# Altium schematic sheet Wires1.SchDoc
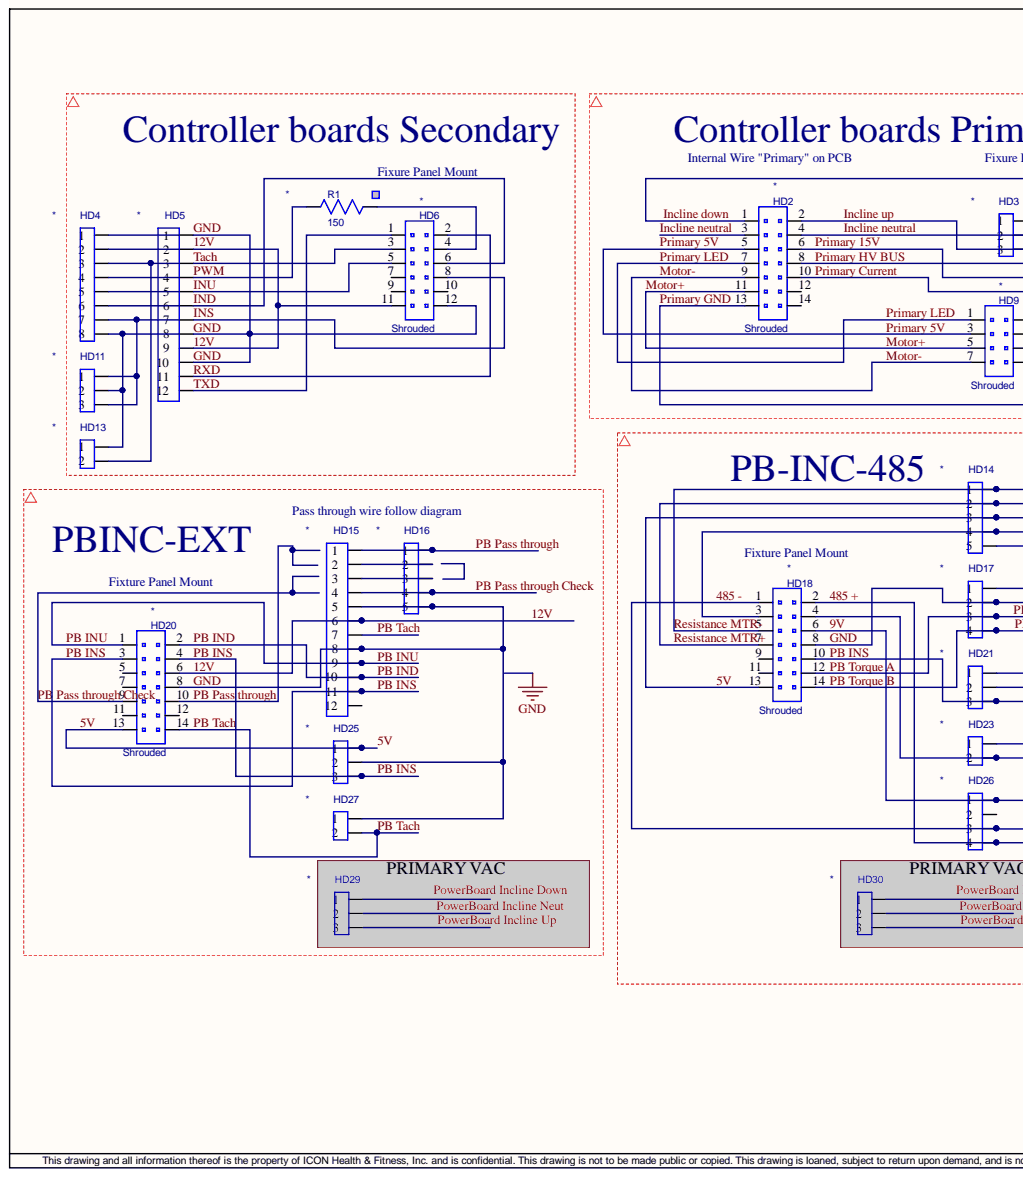
[diagram p0: Wires1.SchDoc, left]
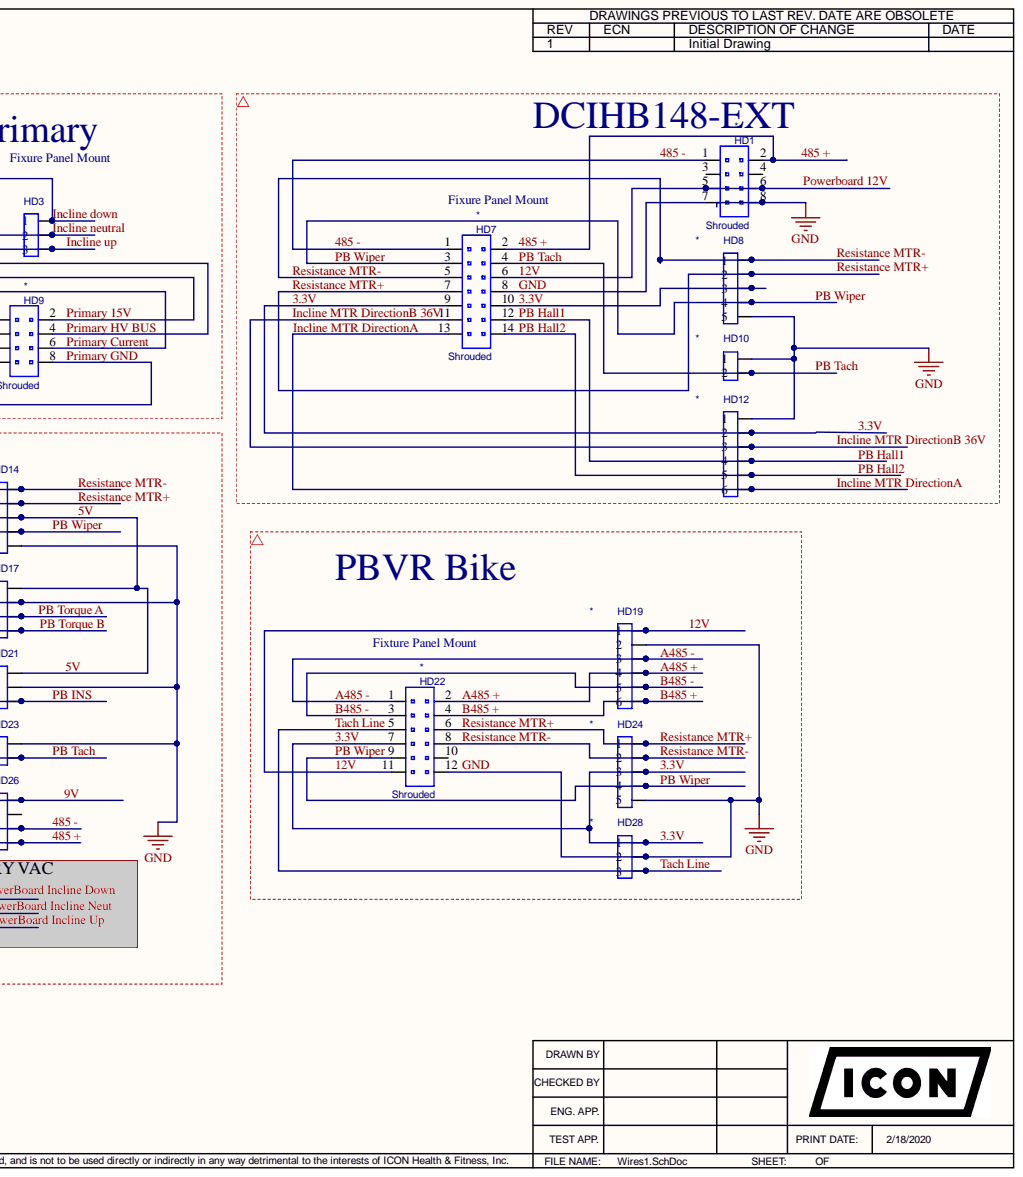
[diagram p1: Wires1.SchDoc, right]

## Parts
HD1  HeaderType: Shrouded  [HDR_2.5MM_8PIN_JST_XAD]  (hidden comment: HDR_8PIN_DUALROW)
HD2  HeaderType: Shrouded  [HDR_2.5MM_14PIN_JST_XAD]  (hidden comment: HDR_14PIN_DUALROW_JST)
HD3  HDR3PIN  [HDR3PIN_JST_XA_2.5MM]  (value not drawn)
HD4  HDR8PIN  [HDR8PIN_JST_XA_2.5MM]  (value not drawn)
HD5  HDR12PIN  [HDR12PIN_JST_XA_2.5MM]  (value not drawn)
HD6  HeaderType: Shrouded  [HDR_2.5MM_12PIN_JST_XAD]  (hidden comment: HDR_12PIN_DUALROW)
HD7  HeaderType: Shrouded  [HDR_2.5MM_14PIN_JST_XAD]  (hidden comment: HDR_14PIN_DUALROW_JST)
HD8  HeaderType: Shrouded  [HDR5PIN_JST_XA_2.5MM]  (hidden comment: HDR5PIN)
HD9  HeaderType: Shrouded  [HDR_2.5MM_8PIN_JST_XAD]  (hidden comment: HDR_8PIN_DUALROW)
HD10  HDR2PIN  [HDR2PIN_JST_XA_2.5MM]  (value not drawn)
HD11  HDR3PIN  [HDR3PIN_JST_XA_2.5MM]  (value not drawn)
HD12  HDR6PIN  [HDR6PIN_JST_XA_2.5MM]  (value not drawn)
HD13  HDR2PIN  [HDR2PIN_JST_XA_2.5MM]  (value not drawn)
HD14  HDR5PIN  [HDR5PIN_JST_XA_2.5MM]  (value not drawn)
HD15  HDR12PIN  [HDR12PIN_JST_XA_2.5MM]  (value not drawn)
HD16  HDR5PIN  [HDR5PIN_JST_XA_2.5MM]  (value not drawn)
HD17  HDR4PIN  [HDR4PIN_JST_XA_2.5MM]  (value not drawn)
HD18  HeaderType: Shrouded  [HDR_2.5MM_14PIN_JST_XAD]  (hidden comment: HDR_14PIN_DUALROW_JST)
HD19  HDR6PIN  [HDR6PIN_JST_XA_2.5MM]  (value not drawn)
HD20  HeaderType: Shrouded  [HDR_2.5MM_14PIN_JST_XAD]  (hidden comment: HDR_14PIN_DUALROW_JST)
HD21  HDR3PIN  [HDR3PIN_JST_XA_2.5MM]  (value not drawn)
HD22  HeaderType: Shrouded  [HDR_2.5MM_12PIN_JST_XAD]  (hidden comment: HDR_12PIN_DUALROW)
HD23  HDR2PIN  [HDR2PIN_JST_XA_2.5MM]  (value not drawn)
HD24  HDR5PIN  [HDR5PIN_JST_XA_2.5MM]  (value not drawn)
HD25  HDR3PIN  [HDR3PIN_JST_XA_2.5MM]  (value not drawn)
HD26  HDR4PIN  [HDR4PIN_JST_XA_2.5MM]  (value not drawn)
HD27  HDR2PIN  [HDR2PIN_JST_XA_2.5MM]  (value not drawn)
HD28  HDR3PIN  [HDR3PIN_JST_XA_2.5MM]  (value not drawn)
HD29  HDR3PIN  [HDR3PIN_JST_XA_2.5MM]  (value not drawn)
HD30  HDR3PIN  [HDR3PIN_JST_XA_2.5MM]  (value not drawn)
R1  value 150  [R400/70100/42]  (hidden comment: R400/107/42_5%)

## Nets
3.3V: HD7.9 HD7.10 HD8.3 HD12.2 HD22.7 HD24.3 HD28.1
5V: HD14.3 HD17.1 HD18.13 HD20.13 HD21.1 HD25.1
9V: HD18.6 HD26.1
12V: HD1.5 HD1.6 HD5.2 HD5.9 HD6.11 HD7.6 HD15.6 HD19.1 HD20.6 HD22.11
485 +: HD1.2 HD7.2 HD18.2 HD26.4
485 -: HD1.1 HD7.1 HD18.1 HD26.3
A485 +: HD19.4 HD22.2
A485 -: HD19.3 HD22.1
B485 +: HD19.6 HD22.4
B485 -: HD19.5 HD22.3
GND: HD1.7 HD1.8 HD5.1 HD5.8 HD5.10 HD6.12 HD7.8 HD8.5 HD10.1 HD12.1 HD14.5 HD15.5 HD15.8 HD16.5 HD17.2 HD18.8 HD19.2 HD20.8 HD21.2 HD22.12 HD23.1 HD24.5 HD25.2 HD27.1 HD28.2
Incline down: HD2.1 HD3.1
Incline MTR DirectionA: HD7.13 HD12.6
Incline MTR DirectionB 36V: HD7.11 HD12.3
Incline neutral: HD2.3 HD2.4 HD3.2
Incline up: HD2.2 HD3.3
IND: HD5.6 HD6.6
INS: HD5.7 HD6.8
INU: HD5.5 HD6.5
Motor+: HD2.11 HD9.5
Motor-: HD2.9 HD9.7
PB Hall1: HD7.12 HD12.4
PB Hall2: HD7.14 HD12.5
PB IND: HD15.10 HD20.2
PB INS: HD15.11 HD18.10 HD20.3 HD20.4 HD21.3 HD25.3
PB INU: HD15.9 HD20.1
PB Pass through: HD15.1 HD16.1 HD20.10
PB Pass through Check: HD15.4 HD16.4 HD20.9
PB Tach: HD7.4 HD10.2 HD15.7 HD18.4 HD20.14 HD23.2 HD27.2
PB Torque A: HD17.3 HD18.12
PB Torque B: HD17.4 HD18.14
PB Wiper: HD7.3 HD8.4 HD14.4 HD18.3 HD22.9 HD24.4
Primary 5V: HD2.5 HD9.3
Primary 15V: HD2.6 HD9.2
Primary Current: HD2.10 HD9.6
Primary GND: HD2.13 HD9.8
Primary HV BUS: HD2.8 HD9.4
Primary LED: HD2.7 HD9.1
PWM: HD5.4 R1.2
Resistance MTR+: HD7.7 HD8.2 HD14.2 HD18.7 HD22.6 HD24.1
Resistance MTR-: HD7.5 HD8.1 HD14.1 HD18.5 HD22.8 HD24.2
RXD: HD5.11 HD6.2
Tach: HD5.3 HD6.3
Tach Line: HD22.5 HD28.3
TXD: HD5.12 HD6.1
NetHD4_3: HD4.3 HD13.2
NetHD4_7: HD4.7 HD11.1 HD11.3
NetHD4_8: HD4.8 HD11.2 HD13.1
NetHD6_4: HD6.4 R1.1
NetHD15_2: HD15.2 HD16.2
NetHD15_3: HD15.3 HD16.3
N00022:
PowerBoard Incline Down:
PowerBoard Incline Neut:
PowerBoard Incline Up:

Not connected: HD1 3 4; HD2 12 14; HD4 1 2 4 5 6; HD6 7 9 10; HD15 12; HD18 9 11; HD20 5 7 11 12; HD22 10; HD26 2; HD29 1 2 3; HD30 1 2 3

## Library data of the parts
HD1 HD9 = 349833: Header, 8 Pins, 2.50mm, Vertical, Through hole, dual row, Shrouded, JST: B08B-XADSS-N-A; Database Table Name: AltiumComponents; Component Kind: Standard; ComponentLink1Description: Datasheet; ComponentLink1URL: \\iconfitness.com\loganfiles\RND Departments\electronics\accel_lib\Datasheets\eXAD1.pdf; ComponentLink4Description: PDM Parts Maintenance; ComponentLink4URL: https://pdm.iconfitness.com/PDM/EBOM/PartsMaintenance/PartsMaintenance.aspx?Editplidx=349833; ComponentType: Header; Cost: 0.17; LeadSpacing: 2.50mm; Package: Vertical, Through hole, dual row; Pins: 8; plIDX: 349833; Value: B08B-XADSS-N-A; Vendor: JST: B08B-XADSS-N-A; CreatedBy: Rui Zhang; LastUpdatedBy: Rui Zhang; Library Name: bome.DbLib
HD2 HD7 HD18 HD20 = 365385: Header, 14 Pins, 2.50mm, Vertical, Through hole, double row, Shrouded, JST: B14B-XADSS-N-A; Database Table Name: AltiumComponents; Component Kind: Standard; ComponentLink1Description: Datasheet; ComponentLink1URL: \\iconfitness.com\loganfiles\RND Departments\electronics\accel_lib\Datasheets\eXA1.pdf; ComponentLink4Description: PDM Parts Maintenance; ComponentLink4URL: https://pdm.iconfitness.com/PDM/EBOM/PartsMaintenance/PartsMaintenance.aspx?Editplidx=365385; ComponentType: Header; Cost: 0; LeadSpacing: 2.50mm; Package: Vertical, Through hole, double row; Pins: 14; plIDX: 365385; Value: B14B-XADSS-N-A; Vendor: JST: B14B-XADSS-N-A; CreatedBy: Ross Bunnell; LastUpdatedBy: Ross Bunnell; Library Name: bome.DbLib
HD3 HD11 HD21 HD25 HD28-HD30 = 342209: Header, 3 Pins, 2.50mm, Vertical, Through hole, single row, Shrouded, JST: B03B-XASK-1-A; Database Table Name: AltiumComponents; Component Kind: Standard; ComponentLink1Description: Datasheet; ComponentLink1URL: \\iconfitness.com\loganfiles\RND Departments\electronics\accel_lib\Datasheets\eXA1.pdf; ComponentLink4Description: PDM Parts Maintenance; ComponentLink4URL: https://pdm.iconfitness.com/PDM/EBOM/PartsMaintenance/PartsMaintenance.aspx?Editplidx=342209; ComponentType: Header; Cost: 0.0592; HeaderType: Shrouded; LeadSpacing: 2.50mm; Package: Vertical, Through hole, single row; Pins: 3; plIDX: 342209; Vendor: JST: B03B-XASK-1-A; CreatedBy: Michael Angeli; LastUpdatedBy: Michael Angeli; Library Name: bome.DbLib
HD4 = 342214: Header, 8 Pins, 2.50mm, Vertical, Through hole, single row, Shrouded, JST: B08B-XASK-1-A; Database Table Name: AltiumComponents; Component Kind: Standard; ComponentLink1Description: Datasheet; ComponentLink1URL: \\iconfitness.com\loganfiles\RND Departments\electronics\accel_lib\Datasheets\eXA1.pdf; ComponentLink4Description: PDM Parts Maintenance; ComponentLink4URL: https://pdm.iconfitness.com/PDM/EBOM/PartsMaintenance/PartsMaintenance.aspx?Editplidx=342214; ComponentType: Header; Cost: 0.1271; HeaderType: Shrouded; LeadSpacing: 2.50mm; Package: Vertical, Through hole, single row; Pins: 8; plIDX: 342214; Vendor: JST: B08B-XASK-1-A; CreatedBy: Michael Angeli; LastUpdatedBy: Michael Angeli; Library Name: bome.DbLib
HD5 HD15 = 342218: Header, 12 Pins, 2.50mm, Vertical, Through hole, single row, Shrouded, JST: B12B-XASK-1-A; Database Table Name: AltiumComponents; Component Kind: Standard; ComponentLink1Description: Datasheet; ComponentLink1URL: \\iconfitness.com\loganfiles\RND Departments\electronics\accel_lib\Datasheets\eXA1.pdf; ComponentLink4Description: PDM Parts Maintenance; ComponentLink4URL: https://pdm.iconfitness.com/PDM/EBOM/PartsMaintenance/PartsMaintenance.aspx?Editplidx=342218; ComponentType: Header; Cost: 0.15; HeaderType: Shrouded; LeadSpacing: 2.50mm; Package: Vertical, Through hole, single row; Pins: 12; plIDX: 342218; Vendor: JST: B12B-XASK-1-A; CreatedBy: Michael Angeli; LastUpdatedBy: Michael Angeli; Library Name: bome.DbLib
HD6 HD22 = 349864: Header, 12 Pins, 2.50mm, Vertical, Through hole, dual row, Shrouded, JST: B12B-XADSS-N-A; Database Table Name: AltiumComponents; Component Kind: Standard; ComponentLink1Description: Datasheet; ComponentLink1URL: \\iconfitness.com\loganfiles\RND Departments\electronics\accel_lib\Datasheets\eXAD1.pdf; ComponentLink4Description: PDM Parts Maintenance; ComponentLink4URL: https://pdm.iconfitness.com/PDM/EBOM/PartsMaintenance/PartsMaintenance.aspx?Editplidx=349864; ComponentType: Header; Cost: 0; LeadSpacing: 2.50mm; Package: Vertical, Through hole, dual row; Pins: 12; plIDX: 349864; Value: B12B-XADSS-N-A; Vendor: JST: B12B-XADSS-N-A; CreatedBy: Rui Zhang; LastUpdatedBy: Rui Zhang; Library Name: bome.DbLib
HD8 HD14 HD16 HD24 = 342211: Header, 5 Pins, 2.50mm, Vertical, Through hole, single row, Shrouded, JST: B05B-XASK-1-A; Database Table Name: AltiumComponents; Component Kind: Standard; ComponentLink1Description: Datasheet; ComponentLink1URL: \\iconfitness.com\loganfiles\RND Departments\electronics\accel_lib\Datasheets\eXA1.pdf; ComponentLink4Description: PDM Parts Maintenance; ComponentLink4URL: https://pdm.iconfitness.com/PDM/EBOM/PartsMaintenance/PartsMaintenance.aspx?Editplidx=342211; ComponentType: Header; Cost: 0.0873; LeadSpacing: 2.50mm; Package: Vertical, Through hole, single row; Pins: 5; plIDX: 342211; Vendor: JST: B05B-XASK-1-A; CreatedBy: Michael Angeli; LastUpdatedBy: Michael Angeli; Library Name: bome.DbLib
  HD14 HD16 HD24: HeaderType: Shrouded
HD10 HD13 HD23 HD27 = 342208: Header, 2 Pins, 2.50mm, Vertical, Through hole, single row, Shrouded, JST: B02B-XASK-1-A; Database Table Name: AltiumComponents; Component Kind: Standard; ComponentLink1Description: Datasheet; ComponentLink1URL: \\iconfitness.com\loganfiles\RND Departments\electronics\accel_lib\Datasheets\eXA1.pdf; ComponentLink4Description: PDM Parts Maintenance; ComponentLink4URL: https://pdm.iconfitness.com/PDM/EBOM/PartsMaintenance/PartsMaintenance.aspx?Editplidx=342208; ComponentType: Header; Cost: 0.0446; HeaderType: Shrouded; LeadSpacing: 2.50mm; Package: Vertical, Through hole, single row; Pins: 2; plIDX: 342208; Vendor: JST: B02B-XASK-1-A; CreatedBy: Michael Angeli; LastUpdatedBy: Michael Angeli; Library Name: bome.DbLib
HD12 HD19 = 342212: Header, 6 Pins, 2.50mm, Vertical, Through hole, single row, Shrouded, JST: B06B-XASK-1-A; Database Table Name: AltiumComponents; Component Kind: Standard; ComponentLink1Description: Datasheet; ComponentLink1URL: \\iconfitness.com\loganfiles\RND Departments\electronics\accel_lib\Datasheets\eXA1.pdf; ComponentLink4Description: PDM Parts Maintenance; ComponentLink4URL: https://pdm.iconfitness.com/PDM/EBOM/PartsMaintenance/PartsMaintenance.aspx?Editplidx=342212; ComponentType: Header; Cost: 0.1028; HeaderType: Shrouded; LeadSpacing: 2.50mm; Package: Vertical, Through hole, single row; Pins: 6; plIDX: 342212; Vendor: JST: B06B-XASK-1-A; CreatedBy: Michael Angeli; LastUpdatedBy: Michael Angeli; Library Name: bome.DbLib
HD17 HD26 = 342210: Header, 4 Pins, 2.50mm, Vertical, Through hole, single row, Shrouded, JST: B04B-XASK-1-A; Database Table Name: AltiumComponents; Component Kind: Standard; ComponentLink1Description: Datasheet; ComponentLink1URL: \\iconfitness.com\loganfiles\RND Departments\electronics\accel_lib\Datasheets\eXA1.pdf; ComponentLink4Description: PDM Parts Maintenance; ComponentLink4URL: https://pdm.iconfitness.com/PDM/EBOM/PartsMaintenance/PartsMaintenance.aspx?Editplidx=342210; ComponentType: Header; Cost: 0.0854; HeaderType: Shrouded; LeadSpacing: 2.50mm; Package: Vertical, Through hole, single row; Pins: 4; plIDX: 342210; Vendor: JST: B04B-XASK-1-A; CreatedBy: Michael Angeli; LastUpdatedBy: Michael Angeli; Library Name: bome.DbLib
R1 = 7423: Resistor, 150, CF, 5%, 0.4 in., 1/4W, Any; Database Table Name: AltiumComponents; LeadSpacing: 0.4 in.; Component Kind: Standard; ComponentLink4Description: PDM Parts Maintenance; ComponentLink4URL: https://pdm.iconfitness.com/PDM/EBOM/PartsMaintenance/PartsMaintenance.aspx?Editplidx=7423; ComponentType: Resistor; Composition: CF; Cost: 0.001; ModifyDate: 03/02/2004 17:13:13; plIDX: 7423; Tolerance: 5%; Vendor: Any; Wattage: 1/4W; Library Name: bome.DbLib
